# Altium schematic sheet LED---MCU.SchDoc(LED---MCU)
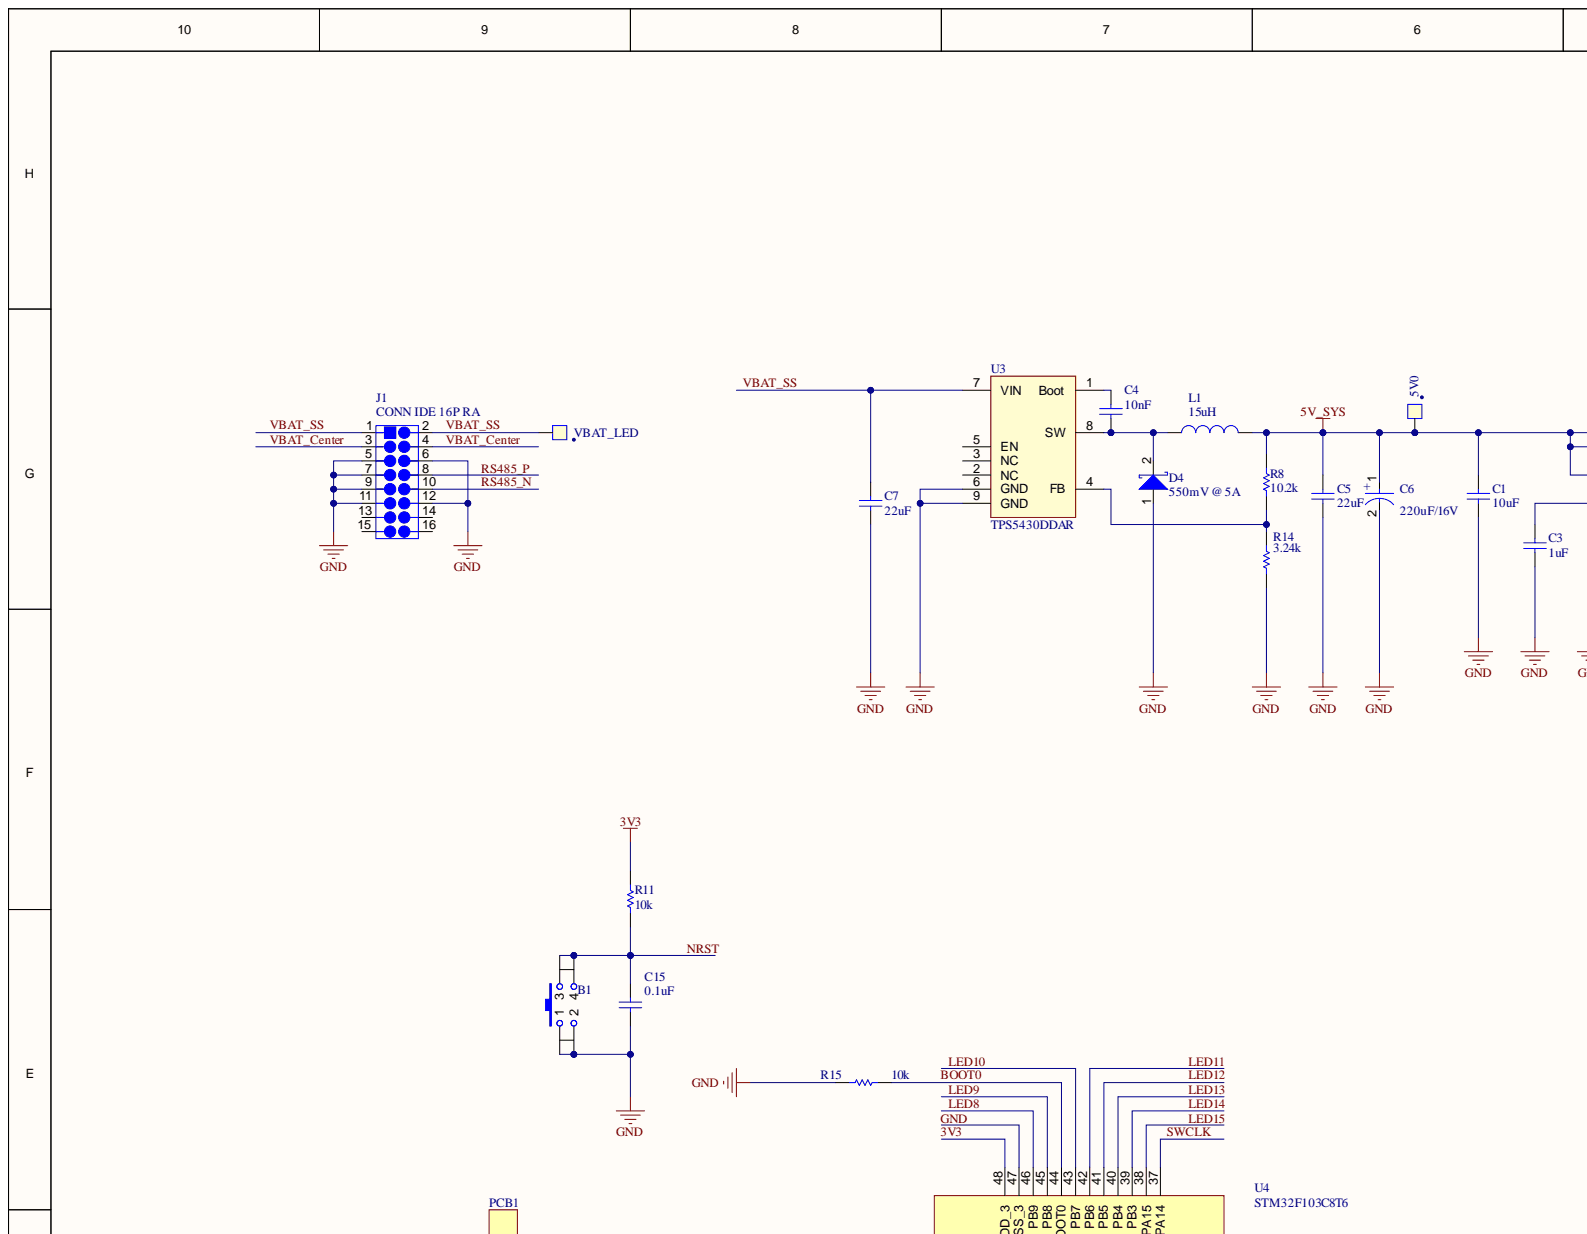
[diagram p0: LED---MCU.SchDoc, top left]
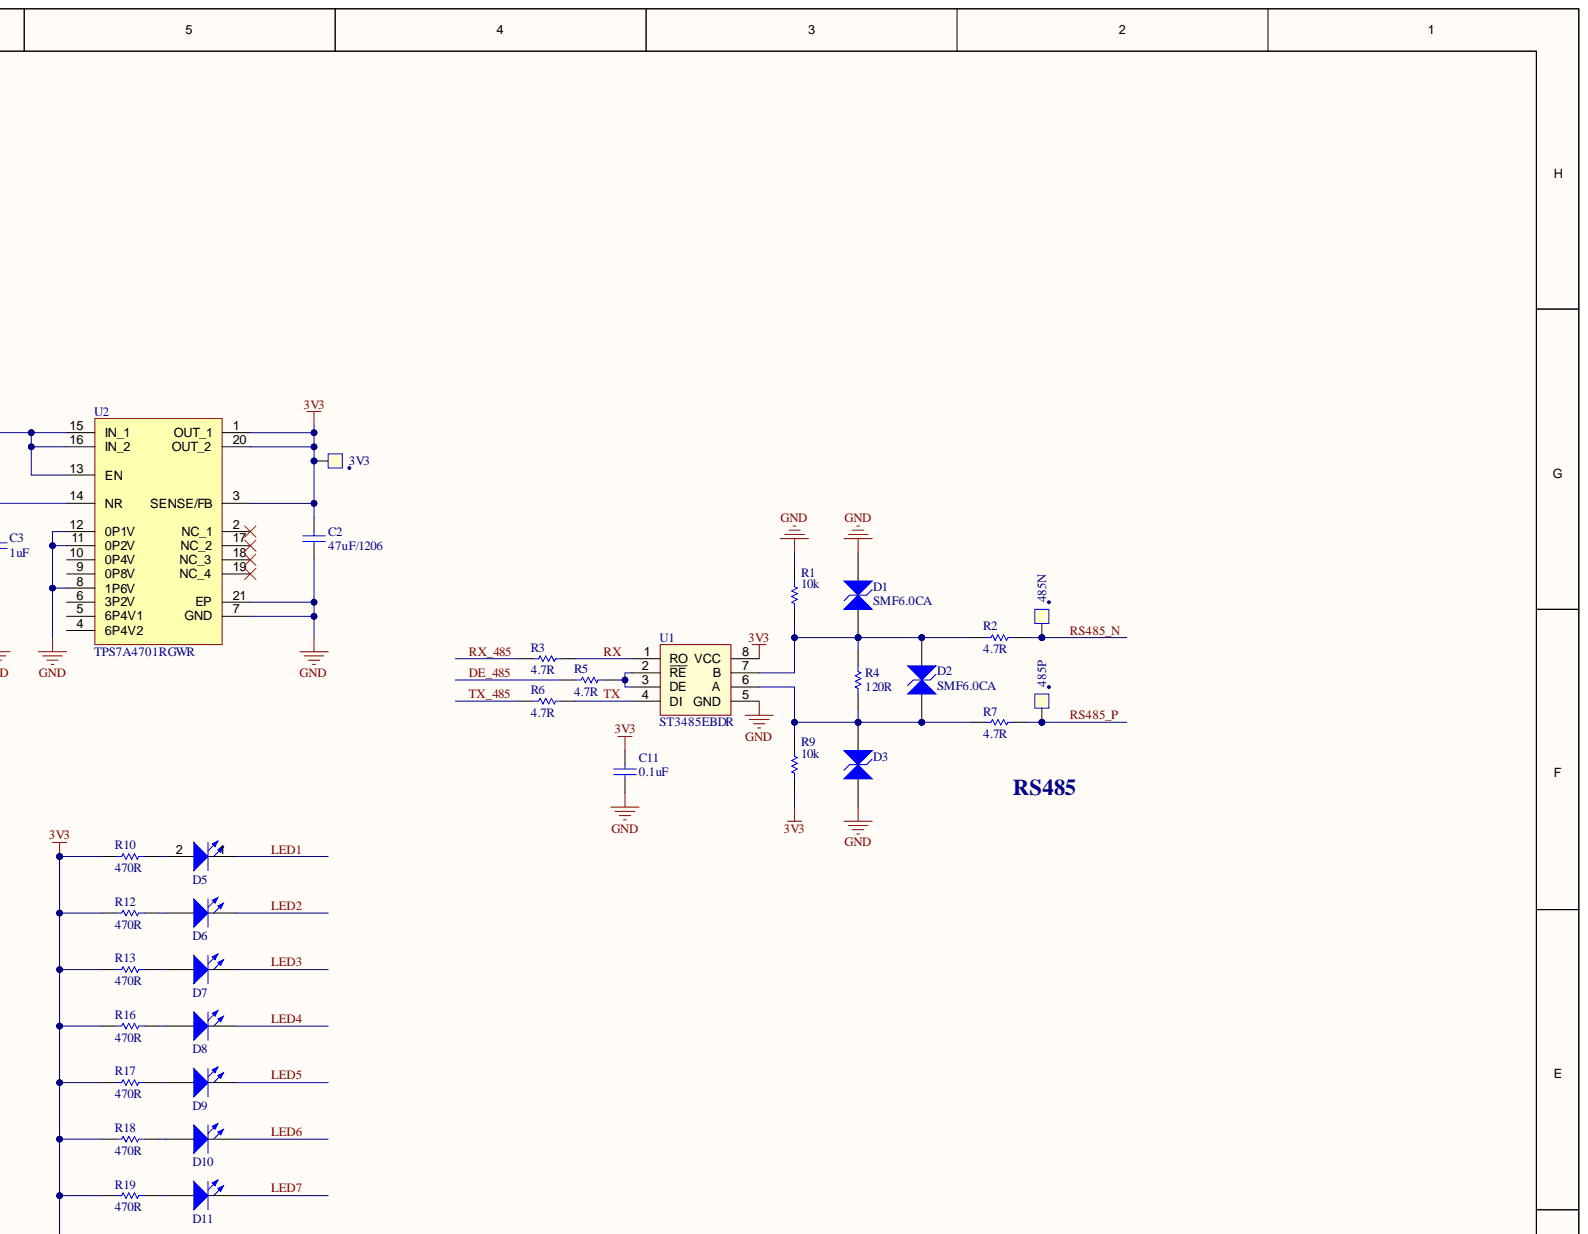
[diagram p1: LED---MCU.SchDoc, top right]
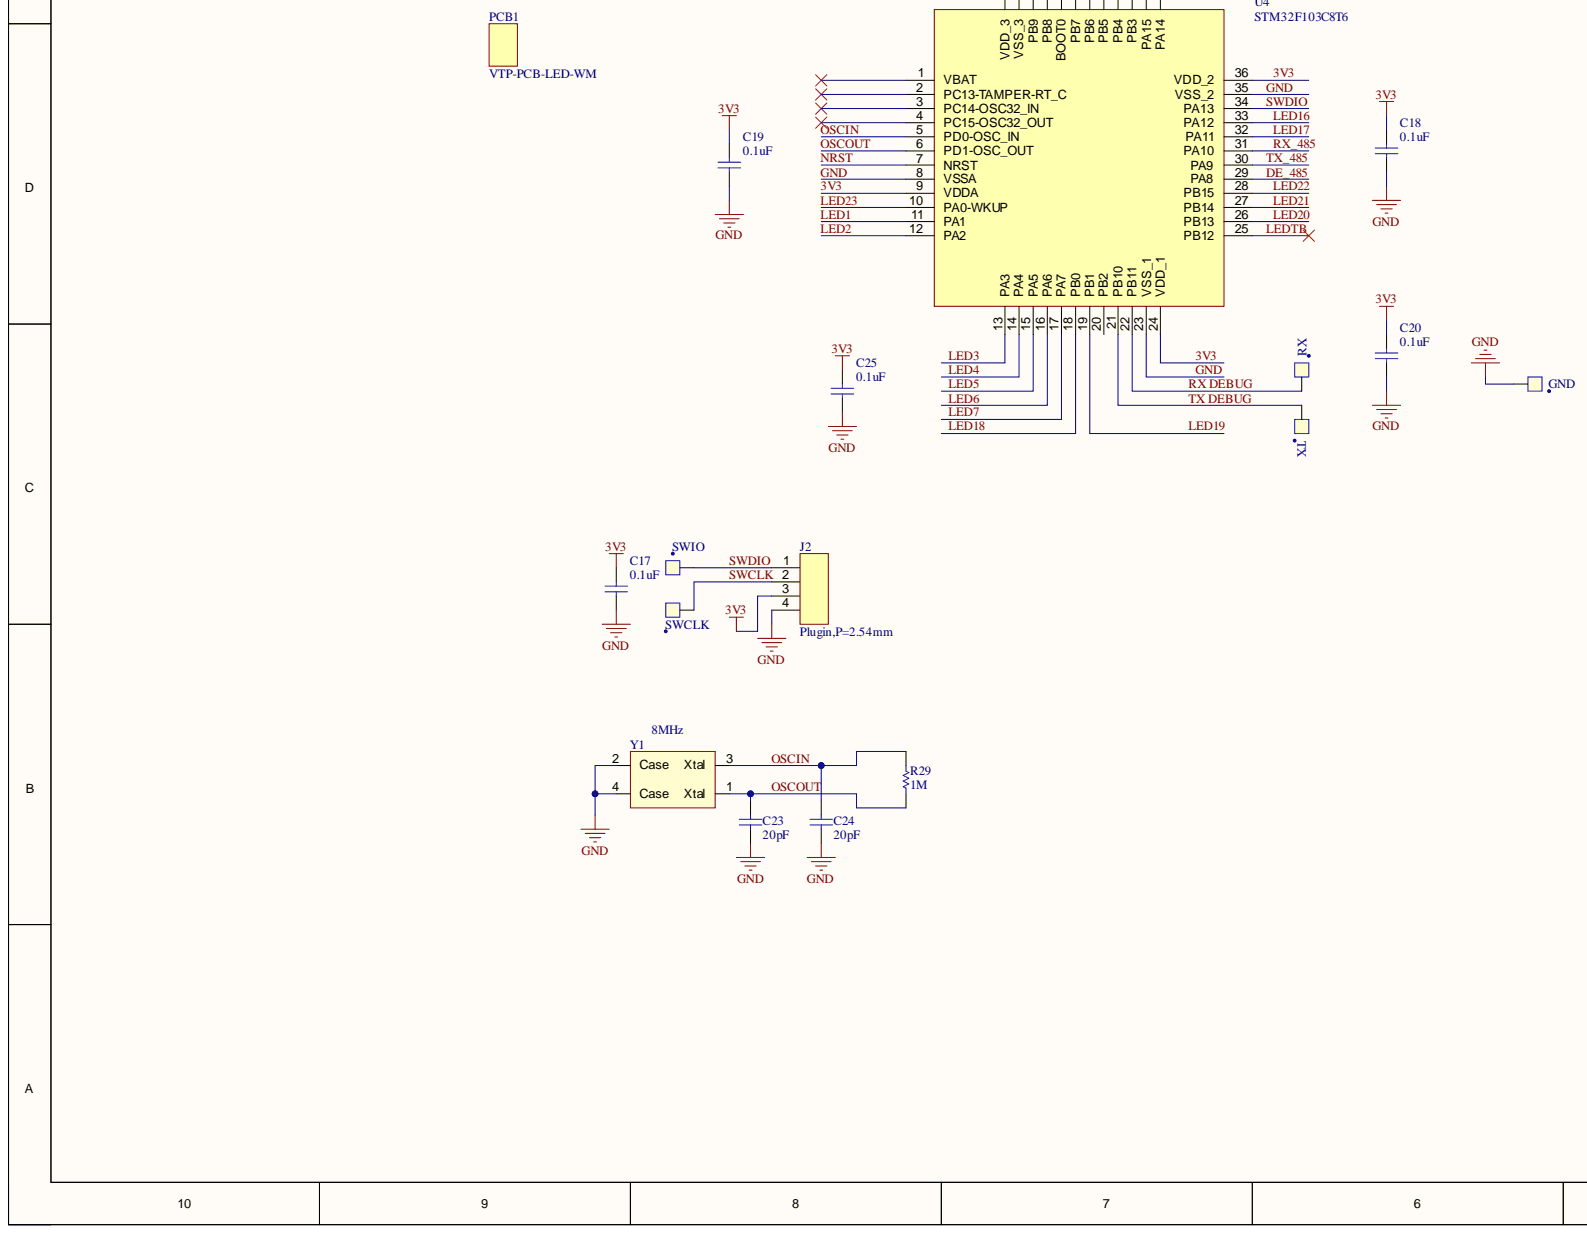
[diagram p2: LED---MCU.SchDoc, bottom left]
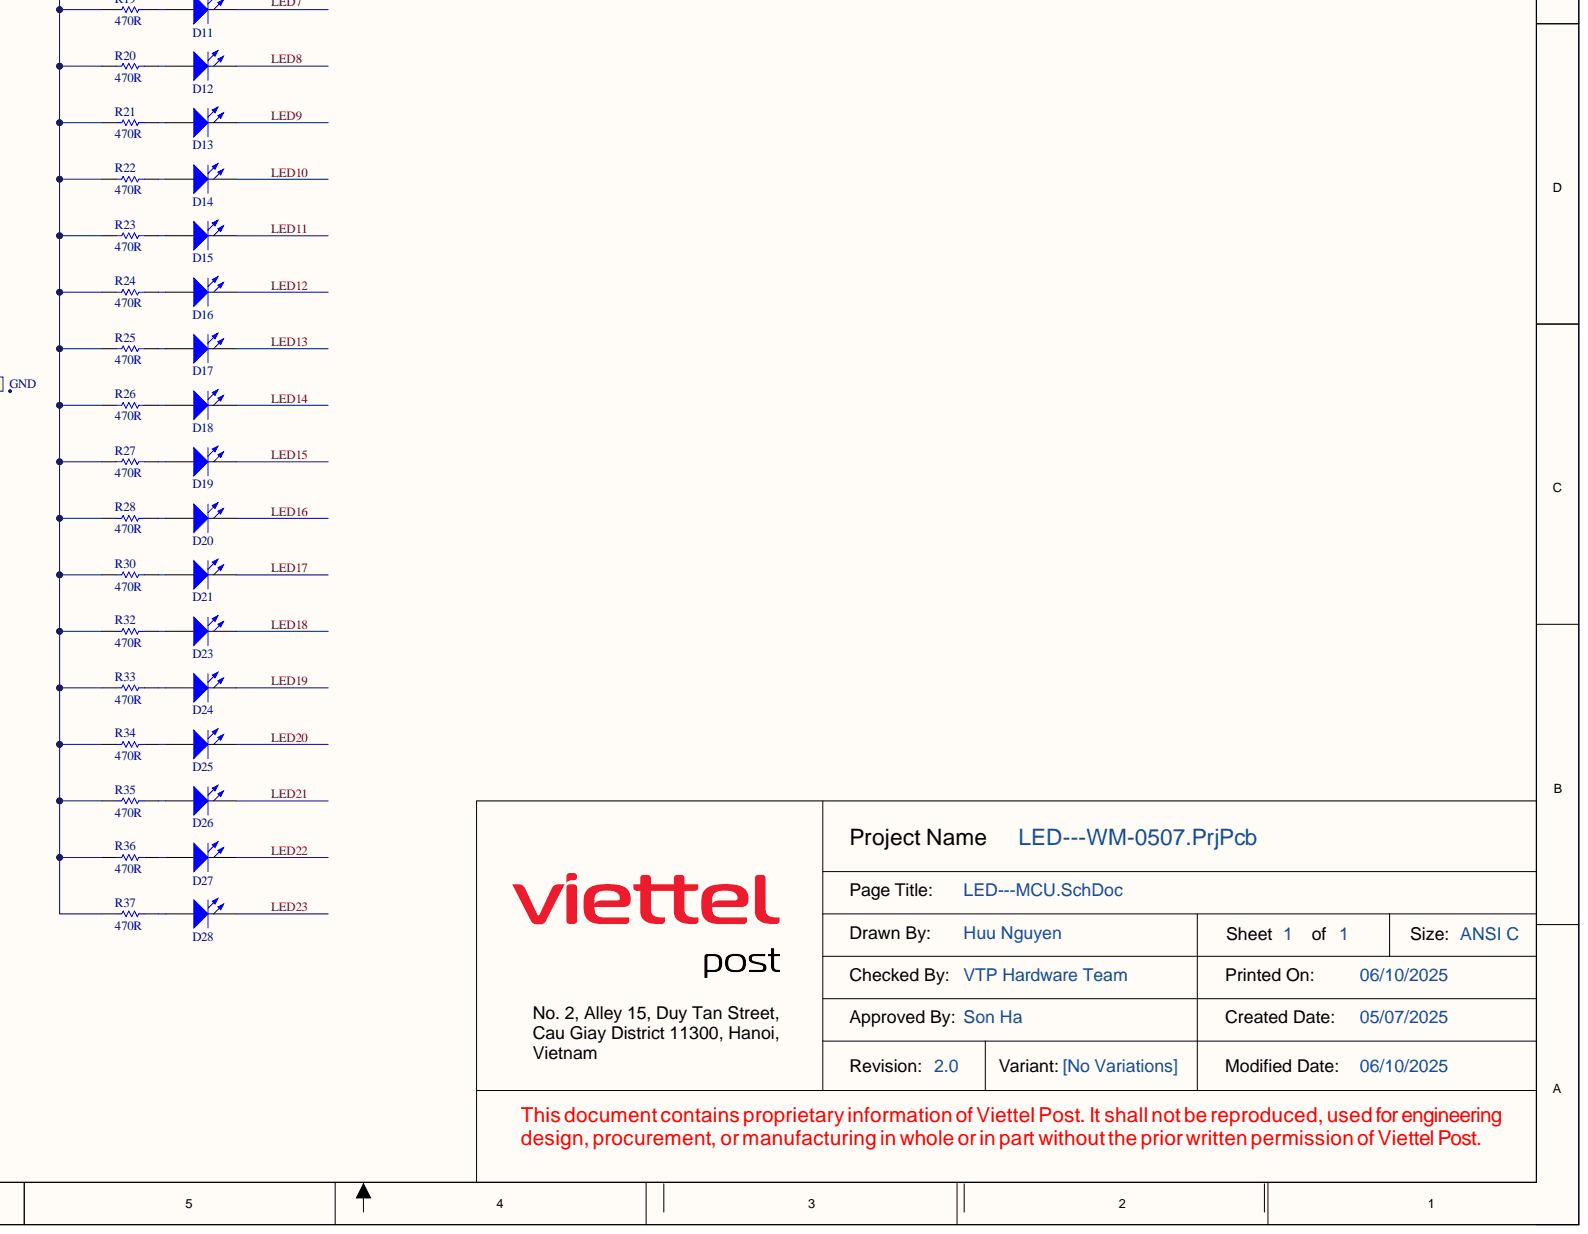
[diagram p3: LED---MCU.SchDoc, bottom right]

## Parts
3V3  NC  [Testpoint 1.5mm]  (value not drawn)  (no BOM)
5V0  NC  [Testpoint 1.5mm]  (value not drawn)  (no BOM)
485N  NC  [Testpoint 1.5mm]  (value not drawn)  (no BOM)
485P  NC  [Testpoint 1.5mm]  (value not drawn)  (no BOM)
B1  1TS005F-2500-5001  [button 250 smd]  (value not drawn)
C1  10uF  [0805C - BIG PAD]
C2  47uF/1206  [1206C]
C3  1uF  [0805C - BIG PAD]
C4  10nF  [0805C - BIG PAD]
C5  22uF  [0805C - BIG PAD]
C6  220uF/16V  [CAP SMD 6.3MM]
C7  22uF  [0805C - BIG PAD]
C11  0.1uF  [0805C - BIG PAD]
C15  0.1uF  [0805C - BIG PAD]
C17  0.1uF  [0805C - BIG PAD]
C18  0.1uF  [0805C - BIG PAD]
C19  0.1uF  [0805C - BIG PAD]
C20  0.1uF  [0805C - BIG PAD]
C23  20pF  [0805C - BIG PAD]
C24  20pF  [0805C - BIG PAD]
C25  0.1uF  [0805C - BIG PAD]
D1  SMF6.0CA  [SOD-123FL-]
D2  SMF6.0CA  [SOD-123FL-]
D3  SMF6.0CA  [SOD-123FL-]  (value not drawn)
D4  550mV @ 5A  [SMAF -1A2K]
D5  RED/0805  [0805 LED]  (value not drawn)
D6  RED/0805  [0805 LED]  (value not drawn)
D7  RED/0805  [0805 LED]  (value not drawn)
D8  RED/0805  [0805 LED]  (value not drawn)
D9  RED/0805  [0805 LED]  (value not drawn)
D10  RED/0805  [0805 LED]  (value not drawn)
D11  RED/0805  [0805 LED]  (value not drawn)
D12  RED/0805  [0805 LED]  (value not drawn)
D13  RED/0805  [0805 LED]  (value not drawn)
D14  RED/0805  [0805 LED]  (value not drawn)
D15  RED/0805  [0805 LED]  (value not drawn)
D16  RED/0805  [0805 LED]  (value not drawn)
D17  RED/0805  [0805 LED]  (value not drawn)
D18  RED/0805  [0805 LED]  (value not drawn)
D19  RED/0805  [0805 LED]  (value not drawn)
D20  RED/0805  [0805 LED]  (value not drawn)
D21  RED/0805  [0805 LED]  (value not drawn)
D23  RED/0805  [0805 LED]  (value not drawn)
D24  RED/0805  [0805 LED]  (value not drawn)
D25  RED/0805  [0805 LED]  (value not drawn)
D26  RED/0805  [0805 LED]  (value not drawn)
D27  RED/0805  [0805 LED]  (value not drawn)
D28  RED/0805  [0805 LED]  (value not drawn)
GND  NC  [Testpoint 1.5mm]  (value not drawn)  (no BOM)
J1  CONN IDE 16P RA  Type: CONN  [CONN IDE 2x8 RA]
J2  Plugin,P=2.54mm  [HDR 1x4 SR 2.54MM]
L1  15uH  [IND 11x10MM]
PCB1  VTP-PCB-LED-WM  (mechanical)
R1  10k  [0805R - BIG PAD]
R2  4.7R  [0805R - BIG PAD]
R3  4.7R  [0805R - BIG PAD]
R4  120R  [0805R - BIG PAD]
R5  4.7R  [0805R - BIG PAD]
R6  4.7R  [0805R - BIG PAD]
R7  4.7R  [0805R - BIG PAD]
R8  10.2k  [0805R - BIG PAD]
R9  10k  [0805R - BIG PAD]
R10  470R  [0805R - BIG PAD]
R11  10k  [0805R - BIG PAD]
R12  470R  [0805R - BIG PAD]
R13  470R  [0805R - BIG PAD]
R14  3.24k  [0805R - BIG PAD]
R15  10k  [0805R - BIG PAD]
R16  470R  [0805R - BIG PAD]
R17  470R  [0805R - BIG PAD]
R18  470R  [0805R - BIG PAD]
R19  470R  [0805R - BIG PAD]
R20  470R  [0805R - BIG PAD]
R21  470R  [0805R - BIG PAD]
R22  470R  [0805R - BIG PAD]
R23  470R  [0805R - BIG PAD]
R24  470R  [0805R - BIG PAD]
R25  470R  [0805R - BIG PAD]
R26  470R  [0805R - BIG PAD]
R27  470R  [0805R - BIG PAD]
R28  470R  [0805R - BIG PAD]
R29  1M  [0805R - BIG PAD]
R30  470R  [0805R - BIG PAD]
R32  470R  [0805R - BIG PAD]
R33  470R  [0805R - BIG PAD]
R34  470R  [0805R - BIG PAD]
R35  470R  [0805R - BIG PAD]
R36  470R  [0805R - BIG PAD]
R37  470R  [0805R - BIG PAD]
RX  NC  [Testpoint 1.5mm]  (value not drawn)  (no BOM)
SWCLK  NC  [Testpoint 1.5mm]  (value not drawn)  (no BOM)
SWIO  NC  [Testpoint 1.5mm]  (value not drawn)  (no BOM)
TX  NC  [Testpoint 1.5mm]  (value not drawn)  (no BOM)
U1  ST3485EBDR  [SOIC8]
U2  TPS7A4701RGWR  [QFN65P500X500X100-21N-D]
U3  TPS5430DDAR  [RT8289]
U4  STM32F103C8T6  [LQFP-48_19.6_393_58X11_SMD]
VBAT_LED  NC  [Testpoint 1.5mm]  (value not drawn)  (no BOM)
Y1  8MHz  [Crystal 3225 - duplicate]

## Nets
5V_SYS: 5V0.1 C1.1 C5.1 C6.1 L1.2 R8.1 U2.13(EN) U2.15(IN_1) U2.16(IN_2)
3V3: 3V3.1 C2.1 C11.2 C17.2 C18.2 C19.2 C20.2 C25.2 J2.3 R9.1 R10.1 R11.1 R12.1 R13.1 R16.1 R17.1 R18.1 R19.1 R20.1 R21.1 R22.1 R23.1 R24.1 R25.1 R26.1 R27.1 R28.1 R30.1 R32.1 R33.1 R34.1 R35.1 R36.1 R37.1 U1.8(VCC) U2.1(OUT_1) U2.3(SENSE/FB) U2.20(OUT_2) U4.9(VDDA) U4.24(VDD_1) U4.36(VDD_2) U4.48(VDD_3)
BOOT0: R15.1 U4.44(BOOT0)
DE_485: R5.2 U4.29(PA8)
GND: B1.1 B1.2 C1.2 C2.2 C3.2 C5.2 C6.2 C7.2 C11.1 C15.1 C17.1 C18.1 C19.1 C20.1 C23.1 C24.1 C25.1 D1.1 D3.2 D4.1 GND.1 J1.5 J1.6 J1.7 J1.9 J1.11 J1.12 J2.4 R1.2 R14.1 R15.2 U1.5(GND) U2.7(GND) U2.8(1P6V) U2.11(0P2V) U2.12(0P1V) U2.21(EP) U3.6(GND) U3.9(GND) U4.8(VSSA) U4.23(VSS_1) U4.35(VSS_2) U4.47(VSS_3) Y1.2(Case) Y1.4(Case)
LED1: D5.1 U4.11(PA1)
LED2: D6.1 U4.12(PA2)
LED3: D7.1 U4.13(PA3)
LED4: D8.1 U4.14(PA4)
LED5: D9.1 U4.15
LED6: D10.1 U4.16(PA6)
LED7: D11.1 U4.17(PA7)
LED8: D12.1 U4.46
LED9: D13.1 U4.45(PB8)
LED10: D14.1 U4.43(PB7)
LED11: D15.1 U4.42(PB6)
LED12: D16.1 U4.41(PB5)
LED13: D17.1 U4.40(PB4)
LED14: D18.1 U4.39(PB3)
LED15: D19.1 U4.38(PA15)
LED16: D20.1 U4.33(PA12)
LED17: D21.1 U4.32(PA11)
LED18: D23.1 U4.18(PB0)
LED19: D24.1 U4.19(PB1)
LED20: D25.1 U4.26(PB13)
LED21: D26.1 U4.27(PB14)
LED22: D27.1 U4.28(PB15)
LED23: D28.1 U4.10(PA0-WKUP)
LEDTB: U4.25(PB12)
NRST: B1.3 B1.4 C15.2 R11.2 U4.7(NRST)
OSCIN: C24.2 R29.1 U4.5(PD0-OSC_IN) Y1.3(Xtal)
OSCOUT: C23.2 R29.2 U4.6(PD1-OSC_OUT) Y1.1(Xtal)
RS485_N: 485N.1 J1.10 R2.1
RS485_P: 485P.1 J1.8 R7.1
RX: R3.1 U1.1(RO)
RX DEBUG: RX.1 U4.22(PB11)
RX_485: R3.2 U4.31(PA10)
SWCLK: J2.2 SWCLK.1 U4.37(PA14)
SWDIO: J2.1 SWIO.1 U4.34(PA13)
TX: R6.1 U1.4(DI)
TX DEBUG: TX.1 U4.21(PB10)
TX_485: R6.2 U4.30(PA9)
VBAT_Center: J1.3 J1.4
VBAT_SS: C7.1 J1.1 J1.2 U3.7(VIN) VBAT_LED.1
NetC3_1: C3.1 U2.14(NR)
NetC4_1: C4.1 D4.2 L1.1 U3.8(SW)
NetC4_2: C4.2 U3.1(Boot)
NetD1_2: D1.2 D2.1 R1.1 R2.2 R4.2 U1.7(B)
NetD2_2: D2.2 D3.1 R4.1 R7.2 R9.2 U1.6(A)
NetD5_2: D5.2 R10.2
NetD6_2: D6.2 R12.2
NetD7_2: D7.2 R13.2
NetD8_2: D8.2 R16.2
NetD9_2: D9.2 R17.2
NetD10_2: D10.2 R18.2
NetD11_2: D11.2 R19.2
NetD12_2: D12.2 R20.2
NetD13_2: D13.2 R21.2
NetD14_2: D14.2 R22.2
NetD15_2: D15.2 R23.2
NetD16_2: D16.2 R24.2
NetD17_2: D17.2 R25.2
NetD18_2: D18.2 R26.2
NetD19_2: D19.2 R27.2
NetD20_2: D20.2 R28.2
NetD21_2: D21.2 R30.2
NetD23_2: D23.2 R32.2
NetD24_2: D24.2 R33.2
NetD25_2: D25.2 R34.2
NetD26_2: D26.2 R35.2
NetD27_2: D27.2 R36.2
NetD28_2: D28.2 R37.2
NetR5_1: R5.1 U1.2(RE) U1.3(DE)
NetR8_2: R8.2 R14.2 U3.4(FB)

Not connected: J1 13 14 15 16; U2 2(NC_1) 4(6P4V2) 5(6P4V1) 6(3P2V) 9(0P8V) 10(0P4V) 17(NC_2) 18(NC_3) 19(NC_4); U3 2(NC) 3(NC) 5(EN); U4 1(VBAT) 2(PC13-TAMPER-RT_C) 3(PC14-OSC32_IN) 4(PC15-OSC32_OUT) 20(PB2)

## Library data of the parts
3V3 5V0 485N 485P GND RX SWCLK SWIO TX VBAT_LED = TP / 30mil_1: In Process: No; Description: NC; LCSC Part #: -; Link: -; Manufacturer: -; Mfr. Part #: -; Package: -; Packaging: -; Process Type: -; Supplier: -; Type: -; Value: NC
B1 = button 250_SMD: None Without bracket 50mA 6mm 70,000 times 250gf 12V 6mm 5mm Round Button Standing paste SPST SMD,6x6mm Tactile Switches ROHS; Manufacturer: HYP (Hongyuan Precision); In Process: Yes; Process Type: SMT; Component Kind: Standard; LCSC Part #: C255812; Link: -; Mfr. Part #: 1TS005F-2500-5001; Package: SMD,6x6mm; Packaging: Tape and Reel; Supplier: LCSC; Type: BUTTON; Value: 1TS005F-2500-5001; Library Name: center board V1 Pi4.IntLib
C1 = Cap-NP-100: 50V 10uF X5R ±10% 0805 Multilayer Ceramic Capacitors MLCC - SMD/SMT ROHS; Manufacturer: Samsung Electro-Mechanics; Mfr. Part #: CL21A106KBYQNNE; In Process: Yes; Process Type: SMT; Type: CAP; Component Kind: Standard; LCSC Part #: C2932476; Library Name: Full.SchLib; Link: -; Package: 0805; Packaging: Tape and Reel; Supplier: LCSC; Value: 10uF
C2 = Cap-NP-100: 25V 47uF X5R ±20% 1206 Multilayer Ceramic Capacitors MLCC - SMD/SMT ROHS; Manufacturer: Murata Electronics; Mfr. Part #: GRM31CR61E476ME44L; In Process: Yes; Process Type: SMT; Type: CAP; Component Kind: Standard; LCSC Part #: C403725; Library Name: Full.SchLib; Link: -; Package: 1206; Packaging: Tape and Reel; Supplier: LCSC; Value: 47uF/1206
C3 = Cap-NP-100: 50V 1uF X7R ±10% 0805 Multilayer Ceramic Capacitors MLCC - SMD/SMT ROHS; Manufacturer: YAGEO; Mfr. Part #: CC0805KKX7R9BB105; In Process: Yes; Process Type: SMT; Type: CAP; Component Kind: Standard; LCSC Part #: C91185; Library Name: Full.SchLib; Link: -; Package: 0805; Packaging: Tape and Reel; Supplier: LCSC; Value: 1uF
C4 = Cap-NP-100: 50V 10nF X7R ±10% 0805 Multilayer Ceramic Capacitors MLCC - SMD/SMT ROHS; Manufacturer: YAGEO; Mfr. Part #: CC0805KRX7R9BB103; In Process: Yes; Process Type: SMT; Type: CAP; Component Kind: Standard; LCSC Part #: C83170; Library Name: Full.SchLib; Link: -; Package: 0805; Packaging: Tape and Reel; Supplier: LCSC; Value: 10nF
C5 C7 = Cap-NP-100: 25V 22uF X5R ±20% 0805 Multilayer Ceramic Capacitors MLCC - SMD/SMT ROHS; Manufacturer: YAGEO; Mfr. Part #: CC0805MKX5R8BB226; In Process: Yes; Process Type: SMT; Type: CAP; Component Kind: Standard; LCSC Part #: C784585; Library Name: Full.SchLib; Link: -; Package: 0805; Packaging: Tape and Reel; Supplier: LCSC; Value: 22uF
C6 = Cap-2200uF/35V: 220uF 16V 110mA@120Hz ±20% SMD,D6.3xL7.7mm Aluminum Electrolytic Capacitors - SMD ROHS; Manufacturer: KNSCHA; Mfr. Part #: RVT220UF16V67RV0015; In Process: Yes; Process Type: SMT; Type: CAP; Component Kind: Standard; LCSC Part #: C2887273; Library Name: LIB_SCH_BOOST.schLib; Link: -; Package: SMD,D6.3xL7.7mm; Packaging: Tape and Reel; Supplier: LCSC; Value: 220uF/16V
C11 C15 C17-C20 C25 = Cap-NP-100: 50V 100nF X7R ±10% 0805 Multilayer Ceramic Capacitors MLCC - SMD/SMT ROHS; Manufacturer: YAGEO; Mfr. Part #: CC0805KRX7R9BB104; In Process: Yes; Process Type: SMT; Type: CAP; Component Kind: Standard; LCSC Part #: C49678; Library Name: Full.SchLib; Link: -; Package: 0805; Packaging: Tape and Reel; Supplier: LCSC; Value: 0.1uF
C23 C24 = Cap-NP-100: 50V 20pF NP0 ±5% 0805 Multilayer Ceramic Capacitors MLCC - SMD/SMT ROHS; Manufacturer: YAGEO; Mfr. Part #: CC0805JRNPO9BN200; In Process: Yes; Process Type: SMT; Type: CAP; Component Kind: Standard; LCSC Part #: C105173; Library Name: Full.SchLib; Link: -; Package: 0805; Packaging: Tape and Reel; Supplier: LCSC; Value: 20pF
D1-D3 = TVS SMF05CA  SOD123: 19.4A 200W 10.3V 6.67V Bidirectional 6V SOD-123FL ESD and Surge Protection (TVS/ESD) ROHS; Manufacturer: MDD(Microdiode Semiconductor); In Process: Yes; Process Type: SMT; Type: DIODE; Component Kind: Standard; LCSC Part #: C123791; Library Name: Full.SchLib; Link: -; Mfr. Part #: SMF6.0CA; Package: SOD-123FL; Packaging: Tape and Reel; Supplier: LCSC; Value: SMF6.0CA
D4 = diode SS14: 40V 550mV@5A 5A SMAF Schottky Diodes ROHS; Manufacturer: MDD(Microdiode Semiconductor); Mfr. Part #: SS54F; In Process: Yes; Process Type: SMT; Type: DIODE; Component Kind: Standard; LCSC Part #: C64882; Library Name: Full.SchLib; Link: -; Package: SMAF; Packaging: Tape and Reel; Supplier: LCSC; Value: 550mV @ 5A
D5-D21 D23-D28 = LED_RED_SMD_0805: 20mA 230mcd Water Clear op View Mount 615nm~630nm Red 120° 2.3V 0805 LED Indication - Discrete ROHS; Manufacturer: XINGLIGHT; Mfr. Part #: XL-2012SURC; In Process: Yes; Process Type: SMT; Component Kind: Standard; LCSC Part #: C965812; Link: -; Package: 0805; Packaging: Tape and Reel; Supplier: LCSC; Type: LED; Value: RED/0805; Library Name: center board V1 Pi4.IntLib
J1 = header 8x2 JILN: 2.54mm Right Angle Gold Brass 2x8P 8 IDC Header 2.54mm 2 Through Hole,Right Angle,P=2.54mm IDC Connectors ROHS; Manufacturer: Ckmtw(Shenzhen Cankemeng); Mfr. Part #: B-3000R16P-0110; In Process: Yes; Process Type: DIP; Value: B-3000R16P-0110; Component Kind: Standard; LCSC Part #: C146623; Library Name: Full.SchLib; Link: -; Package: Through Hole,Right Angle,P=2.54mm; Packaging: -; Supplier: LCSC
J2 = CON HDR 4 PIN 1X4 2.5MM XHB-4A: 250V 3A Straight Policy 2.5mm 4P 6mm -40℃~+105℃ 3mm 2.54mm Single Row Black 1x4P Plugin,P=2.54mm Pin Headers ROHS; Manufacturer: XFCN; Mfr. Part #: PZ254V-11-04P; In Process: Yes; Process Type: DIP; Component Kind: Standard; LCSC Part #: C2691448; Link: -; Package: Plugin,P=2.54mm; Packaging: -; Supplier: LCSC; Type: CONN; Value: Plugin,P=2.54mm; Library Name: center board V1 Pi4.IntLib
L1 = inductor 2.2uF: 6.25A 15uH ±20% 6A 40mΩ SMD,11.6x10.1mm Inductors (SMD) ROHS; Manufacturer: SHOU HAN; Mfr. Part #: CYA1040-15UH; In Process: Yes; Process Type: SMT; Type: IND; Component Kind: Standard; LCSC Part #: C6364678; Library Name: Full.SchLib; Link: -; Package: SMD,11.6x10.1mm; Packaging: Tape and Reel; Supplier: LCSC; Value: 15uH
PCB1 = CON Housings 4PIN 1x4 XHB－4Y: PCB-LED; Manufacturer: JLCPCB; In Process: Yes; Process Type: SMT; LCSC Part #: -; Link: -; Mfr. Part #: VTP-PCB-LED-WM; Package: -; Packaging: -; Supplier: -; Type: PCB; Value: VTP-PCB-LED-WM; Library Name: VTP_TruckBoxVolMea.SCHLIB
R1 R9 R11 R15 = Res0.3: 125mW Thick Film Resistors 150V ±100ppm/℃ ±1% 10kΩ 0805 Chip Resistor - Surface Mount ROHS; Manufacturer: YAGEO; Mfr. Part #: RC0805FR-0710KL; In Process: Yes; Process Type: SMT; Component Kind: Standard; LCSC Part #: C84376; Library Name: Full.SchLib; Link: -; Package: 0805; Packaging: Tape and Reel; Supplier: LCSC; Type: RES; Value: 10k
R2 R3 R5-R7 = Res0.3: 125mW Thick Film Resistors 150V ±1% ±200ppm/℃ 4.7Ω 0805 Chip Resistor - Surface Mount ROHS; Manufacturer: YAGEO; Mfr. Part #: RC0805FR-074R7L; In Process: Yes; Process Type: SMT; Component Kind: Standard; LCSC Part #: C137513; Library Name: Full.SchLib; Link: -; Package: 0805; Packaging: Tape and Reel; Supplier: LCSC; Type: RES; Value: 4.7R
R4 = Res0.3: 125mW Thick Film Resistor 150V ±100ppm/℃ ±1% 120Ω 0805 Chip Resistor - Surface Mount ROHS; Manufacturer: YAGEO; Mfr. Part #: RC0805FR-07120RL; In Process: Yes; Process Type: SMT; Component Kind: Standard; LCSC Part #: C114245; Library Name: Full.SchLib; Link: -; Package: 0805; Packaging: Tape and Reel; Supplier: LCSC; Type: RES; Value: 120R
R8 = Res0.3: 125mW Thin Film Resistor ±25ppm/℃ ±0.1% 10.2kΩ 0805 Chip Resistor - Surface Mount ROHS; Manufacturer: YAGEO; Mfr. Part #: RT0805BRD0710K2L; In Process: Yes; Process Type: SMT; Component Kind: Standard; LCSC Part #: C865134; Library Name: Full.SchLib; Link: -; Package: 0805; Packaging: Tape and Reel; Supplier: LCSC; Type: RES; Value: 10.2k
R10 R12 R13 R16-R28 R30 R32-R37 = Res0.3: 125mW Thick Film Resistors 150V ±100ppm/℃ ±1% 470Ω 0805 Chip Resistor - Surface Mount ROHS; Manufacturer: YAGEO; Mfr. Part #: RC0805FR-07470RL; In Process: Yes; Process Type: SMT; Component Kind: Standard; LCSC Part #: C114564; Library Name: Full.SchLib; Link: -; Package: 0805; Packaging: Tape and Reel; Supplier: LCSC; Type: RES; Value: 470R
R14 = Res0.3: 0.125W Thick Film Resistors 150V ±100ppm/℃ ±1% 3.24kΩ 0805 Chip Resistor - Surface Mount ROHS; Manufacturer: UNI-ROYAL(Uniroyal Elec); Mfr. Part #: 0805W8F3241T5E; In Process: Yes; Process Type: SMT; Component Kind: Standard; LCSC Part #: C17609; Library Name: Full.SchLib; Link: -; Package: 0805; Packaging: Tape and Reel; Supplier: LCSC; Type: RES; Value: 3.24k
R29 = Res0.3: 125mW Thick Film Resistors 150V ±100ppm/℃ ±1% 1MΩ 0805 Chip Resistor - Surface Mount ROHS; Manufacturer: YAGEO; Mfr. Part #: RC0805FR-071ML; In Process: Yes; Process Type: SMT; Component Kind: Standard; LCSC Part #: C107700; Library Name: Full.SchLib; Link: -; Package: 0805; Packaging: Tape and Reel; Supplier: LCSC; Type: RES; Value: 1M
U1 = RS 485 SIT3485ESA: Transceiver SO-8 RS-485 / RS-422 ICs ROHS; Manufacturer: STMicroelectronics; In Process: Yes; Process Type: SMT; Component Kind: Standard; LCSC Part #: C75809; Library Name: Full.SchLib; Link: -; Mfr. Part #: ST3485EBDR; Package: SOP-8; Packaging: Tape and Reel; Supplier: LCSC; Type: IC; Value: ST3485EBDR
U2 = TPS7A4701RGWR: 78dB@(1kHz) 1A Adjustable 1.4V~34V Positive electrode 35V VQFN-20-EP(5x5) Voltage Regulators - Linear, Low Drop Out (LDO) Regulators ROHS; Manufacturer: Texas Instruments; In Process: Yes; Process Type: SMT; Component Kind: Standard; LCSC Part #: C90084; Library Name: SamacSys.SchLib; Link: -; Mfr. Part #: TPS7A4701RGWR; Package: VQFN-20-EP(5x5); Packaging: Tape and Reel; Supplier: LCSC; Type: IC; Value: TPS7A4701RGWR
U3 = Buck RT8289_SOIC8: Step-down type Adjustable 1.221V~32.04V 3A 5.5V~36V SOIC-8-EP DC-DC Converters ROHS; Manufacturer: Texas Instruments; In Process: Yes; Process Type: SMT; Component Kind: Standard; LCSC Part #: C9864; Link: -; Mfr. Part #: TPS5430DDAR; Package: SOIC-8-EP; Packaging: Tape and Reel; Supplier: LCSC; Type: IC; Value: TPS5430DDAR; Library Name: Doc Charger.SCHLIB
U4 = IC_STM32F103C8T6: ARM-M3 72MHz LQFP-48(7x7) Microcontrollers (MCU/MPU/SOC) ROHS; Manufacturer: STMicroelectronics; In Process: Yes; Process Type: SMT; Component Kind: Standard; LCSC Part #: C8734; Link: -; Mfr. Part #: STM32F103C8T6; Package: LQFP48; Packaging: Tape and Reel; Supplier: LCSC; Type: IC; Value: STM32F103C8T6; Library Name: Altium_Lib_by_KhueNguyenCreator.IntLib
Y1 = Crystal FL0800008Q: 8MHz Surface Mount Crystal 12pF ±10ppm ±20ppm SMD3225-4P Crystals ROHS; Manufacturer: YXC Crystal Oscillators; Mfr. Part #: X32258MOB4SI; In Process: Yes; Process Type: SMT; Component Kind: Standard; LCSC Part #: C2682775; Library Name: Full.SchLib; Link: -; Package: SMD3225-4P; Packaging: Tape and Reel; Supplier: LCSC; Type: CRYSTAL; Value: 8MHz
